# Altium schematic sheet MCU.SchDoc(MCU)
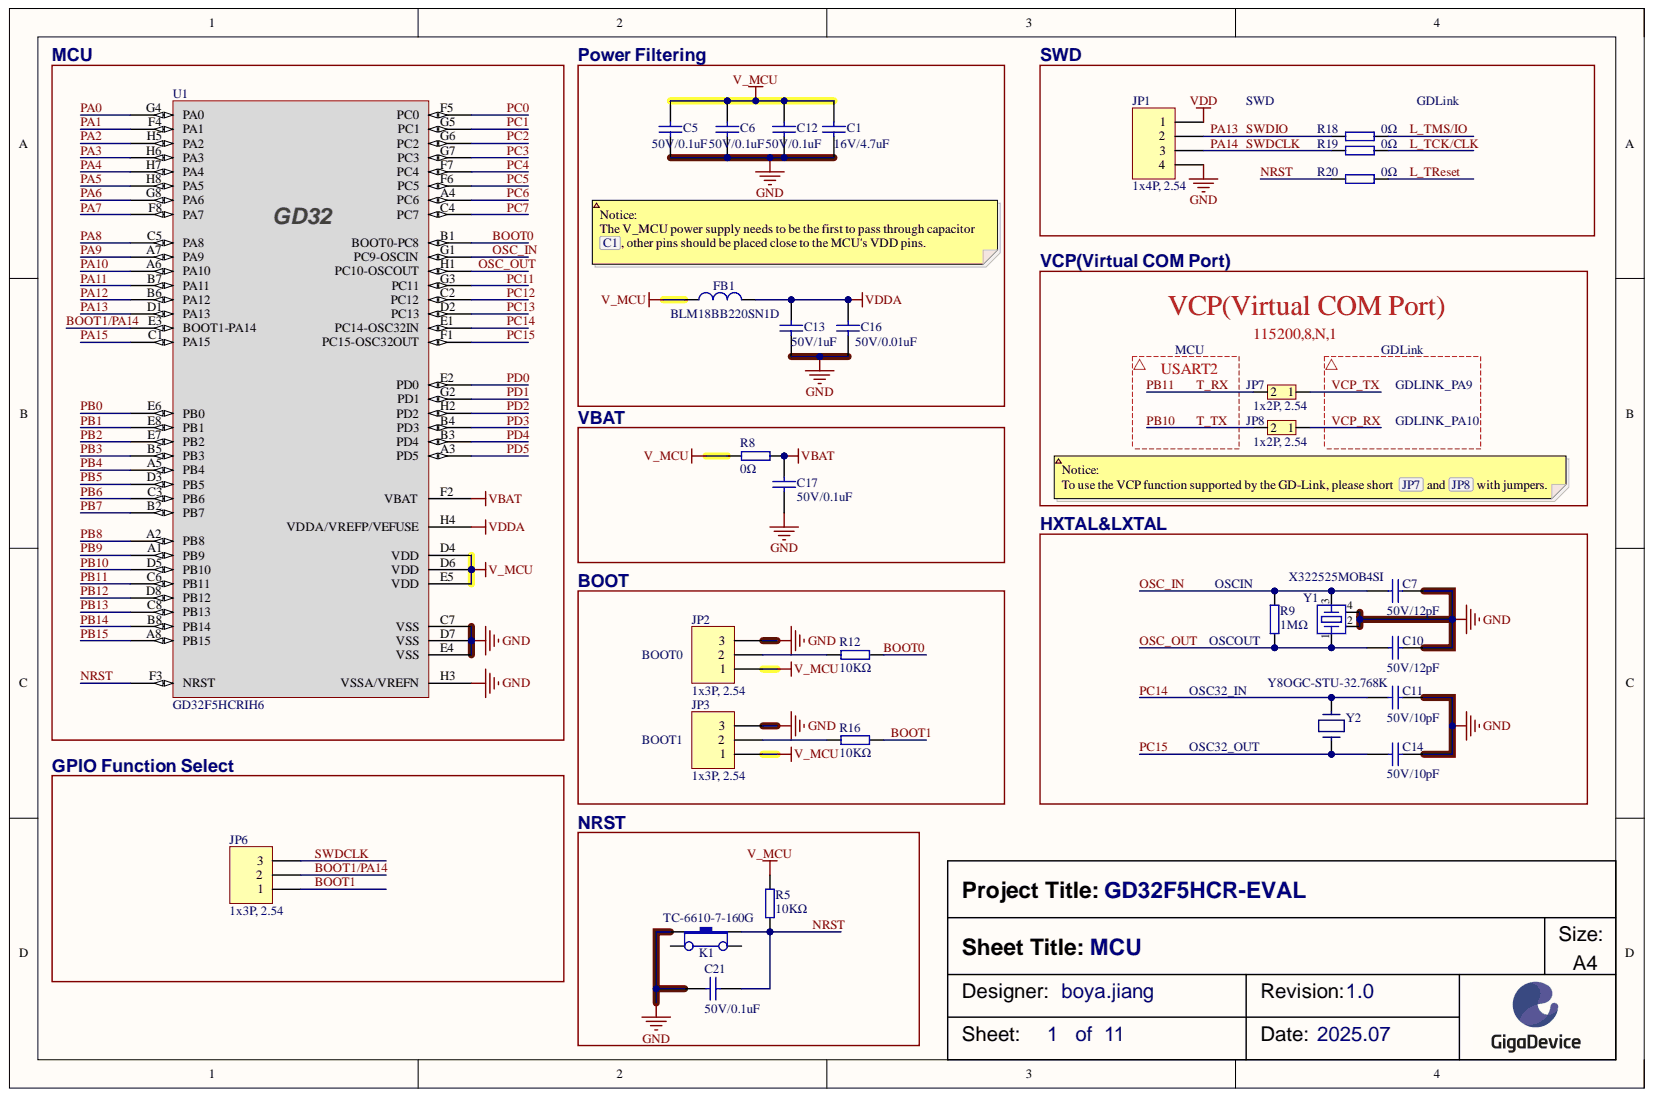
[diagram p0: MCU.SchDoc]

## Parts
C1  16V/4.7uF  [0603-C]
C5  50V/0.1uF  [0402-C]
C6  50V/0.1uF  [0402-C]
C7  50V/12pF  [0603-C]
C10  50V/12pF  [0603-C]
C11  50V/10pF  [0603-C]
C12  50V/0.1uF  [0402-C]
C13  50V/1uF  [0402-C]
C14  50V/10pF  [0603-C]
C16  50V/0.01uF  [0402-C]
C17  50V/0.1uF  [0402-C]
C21  50V/0.1uF  [0603-C]
FB1  BLM18BB220SN1D  [0603-R]
JP1  1x4P, 2.54  [SIP-4]
JP2  1x3P, 2.54  [SIP-3]
JP3  1x3P, 2.54  [SIP-3]
JP6  1x3P, 2.54  [SIP-3]
JP7  1x2P, 2.54  [SIP-2]
JP8  1x2P, 2.54  [SIP-2]
K1  TC-6610-7-160G  [K-1102B]
R5  10KΩ  [0603-R]
R8  0Ω  [0402-R]
R9  1MΩ  [0603-R]
R12  10KΩ  [0603-R]
R16  10KΩ  [0603-R]
R18  0Ω  [0603-R]
R19  0Ω  [0603-R]
R20  0Ω  [0603-R]
U1  GD32F5HCRIH6  [BGA64_5x5X0.80-32101S]
Y1  X322525MOB4SI  [SMD3225-4P]
Y2  Y8OGC-STU-32.768K  [DT-38]

## Nets
GND: C1.1 C5.1 C6.1 C7.1 C10.1 C11.2 C12.1 C13.2 C14.1 C16.2 C17.2 C21.2 JP1.4 JP2.3 JP3.3 K1.1 U1.C7(VSS) U1.D7(VSS) U1.E4(VSS) U1.H3(VSSA/VREFN) Y1.2 Y1.4
V_MCU: C1.2 C5.2 C6.2 C12.2 FB1.1 JP2.1 JP3.1 R5.2 R8.2 U1.D4(VDD) U1.D6(VDD) U1.E5(VDD)
VBAT: C17.1 R8.1 U1.F2(VBAT)
VDD: JP1.1
VDDA: C13.1 C16.1 FB1.2 U1.H4(VDDA/VREFP/VEFUSE)
BOOT0: R12.1 U1.B1(BOOT0-PC8)
BOOT1: JP6.1 R16.1
BOOT1/PA14: JP6.2 U1.E3(BOOT1-PA14)
L_TCK/CLK: R19.1
L_TMS/IO: R18.1
L_TReset: R20.1
NRST: C21.1 K1.3 R5.1 R20.2 U1.F3(NRST)
OSC_IN: C7.2 R9.2 U1.G1(PC9-OSCIN) Y1.3
OSC_OUT: C10.2 R9.1 U1.H1(PC10-OSCOUT) Y1.1
PA0: U1.G4(PA0)
PA1: U1.F4(PA1)
PA2: U1.H5(PA2)
PA3: U1.H6(PA3)
PA4: U1.H7(PA4)
PA5: U1.H8(PA5)
PA6: U1.G8(PA6)
PA7: U1.F8(PA7)
PA8: U1.C5(PA8)
PA9: U1.A7(PA9)
PA10: U1.A6(PA10)
PA11: U1.B7(PA11)
PA12: U1.B6(PA12)
PA13: JP1.2 R18.2 U1.D1(PA13)
PA14: JP1.3 JP6.3 R19.2
PA15: U1.C1(PA15)
PB0: U1.E6(PB0)
PB1: U1.E8(PB1)
PB2: U1.E7(PB2)
PB3: U1.B5(PB3)
PB4: U1.A5(PB4)
PB5: U1.D3(PB5)
PB6: U1.C3(PB6)
PB7: U1.B2(PB7)
PB8: U1.A2(PB8)
PB9: U1.A1(PB9)
PB10: JP8.2 U1.D5(PB10)
PB11: JP7.2 U1.C6(PB11)
PB12: U1.D8(PB12)
PB13: U1.C8(PB13)
PB14: U1.B8(PB14)
PB15: U1.A8(PB15)
PC0: U1.F5(PC0)
PC1: U1.G5(PC1)
PC2: U1.G6(PC2)
PC3: U1.G7(PC3)
PC4: U1.F7(PC4)
PC5: U1.F6(PC5)
PC6: U1.A4(PC6)
PC7: U1.C4(PC7)
PC11: U1.G3(PC11)
PC12: U1.C2(PC12)
PC13: U1.D2(PC13)
PC14: C11.1 U1.E1(PC14-OSC32IN) Y2.2
PC15: C14.2 U1.F1(PC15-OSC32OUT) Y2.1
PD0: U1.E2(PD0)
PD1: U1.G2(PD1)
PD2: U1.H2(PD2)
PD3: U1.B4(PD3)
PD4: U1.B3(PD4)
PD5: U1.A3(PD5)
VCP_RX: JP8.1
VCP_TX: JP7.1
NetJP2_2: JP2.2 R12.2
NetJP3_2: JP3.2 R16.2

Not connected: K1 2 4

## Library data of the parts
C1 = 50V/0.1uF: 表贴电容, X7R; Component Kind: Standard; Library Name: KLTC-电容.SchLib
C5 C6 C12 C13 C16 C17 C21 = 50V/0.1uF: 表贴电容; Component Kind: Standard; Library Name: KLTC-电容.SchLib
C7 C10 = 50V/20pF: 表贴电容; Component Kind: Standard; Library Name: KLTC-电容.SchLib
C11 C14 = 50V/10pF: 表贴电容; Component Kind: Standard; Library Name: KLTC-电容.SchLib
FB1 = BLM21AG221SN1D: 表贴磁珠, 22Ω@100MHz, DCR:200mΩ, 700mA; Component Kind: Standard; Library Name: KLTC-电感及磁珠.SchLib
JP1 = HEADER 4: 单排插针; Note: 不焊; Component Kind: Standard; Library Name: KLTC-按键及接插件.SchLib
JP2 JP3 JP6 = HEADER 3: 单排插针; Component Kind: Standard; Library Name: KLTC-按键及接插件.SchLib
JP7 JP8 = HEADER 1x2: 单排插针; Component Kind: Standard; Library Name: boyajiang.SchLib
K1 = K-1102B: 直插6*6*7mm轻触按键开关, 单刀单掷, 1.6N; Component Kind: Standard; Library Name: KLTC-按键及接插件.SchLib
R5 R12 R16 = 10KΩ: 表贴电阻; Component Kind: Standard; Library Name: KLTC-电阻.SchLib
R8 R9 = 1MΩ: 表贴电阻; Component Kind: Standard; Library Name: KLTC-电阻.SchLib
R18-R20 = 1.5KΩ: 表贴电阻; Component Kind: Standard; Library Name: KLTC-电阻.SchLib
U1 = GD32W513RxHx: 表贴MCU; Database Table Name: GD-MCU; Note: GD供料; Component Kind: Standard; ID: GD32W513RxHx; Library Name: SVNDatabase.SVNDbLib
Y1 = X322525MOB4SI: 表贴无源晶振, SMD3225-4P, 12pF; Manufacturer: JWT(晶威特); Add into BOM: yes; Device: CF4025M00012; Frequency Stability: ±20ppm; Frequency Tolerance: ±10ppm; Name: 25MHz; Value: 25MHz; Component Kind: Standard; Convert to PCB: yes; Datasheet: https://atta.szlcsc.com/upload/public/pdf/source/20230519/8BD53FE1B1EDD8711DA53080BED03017.pdf; LCSC Part Name: 25MHz ±10ppm 12pF; Load Capacitance: 12pF; Manufacturer Part: CF4025M00012; PCB3D: CRYSTAL-SMD_4P-L3.2-W2.5-BL_SIT8008BI; Supplier: LCSC; Supplier Footprint: SMD3225-4P; Supplier Part: C5765971; Symbol: CF4025M00012; Library Name: boyajiang.SchLib
Y2 = Y8OGC-STU-32.768K: 直插晶振, 32.678KHz, 12.5pF, DT-38; Component Kind: Standard; Library Name: boyajiang.SchLib
